# Altium schematic sheet Magnetometer.SchDoc
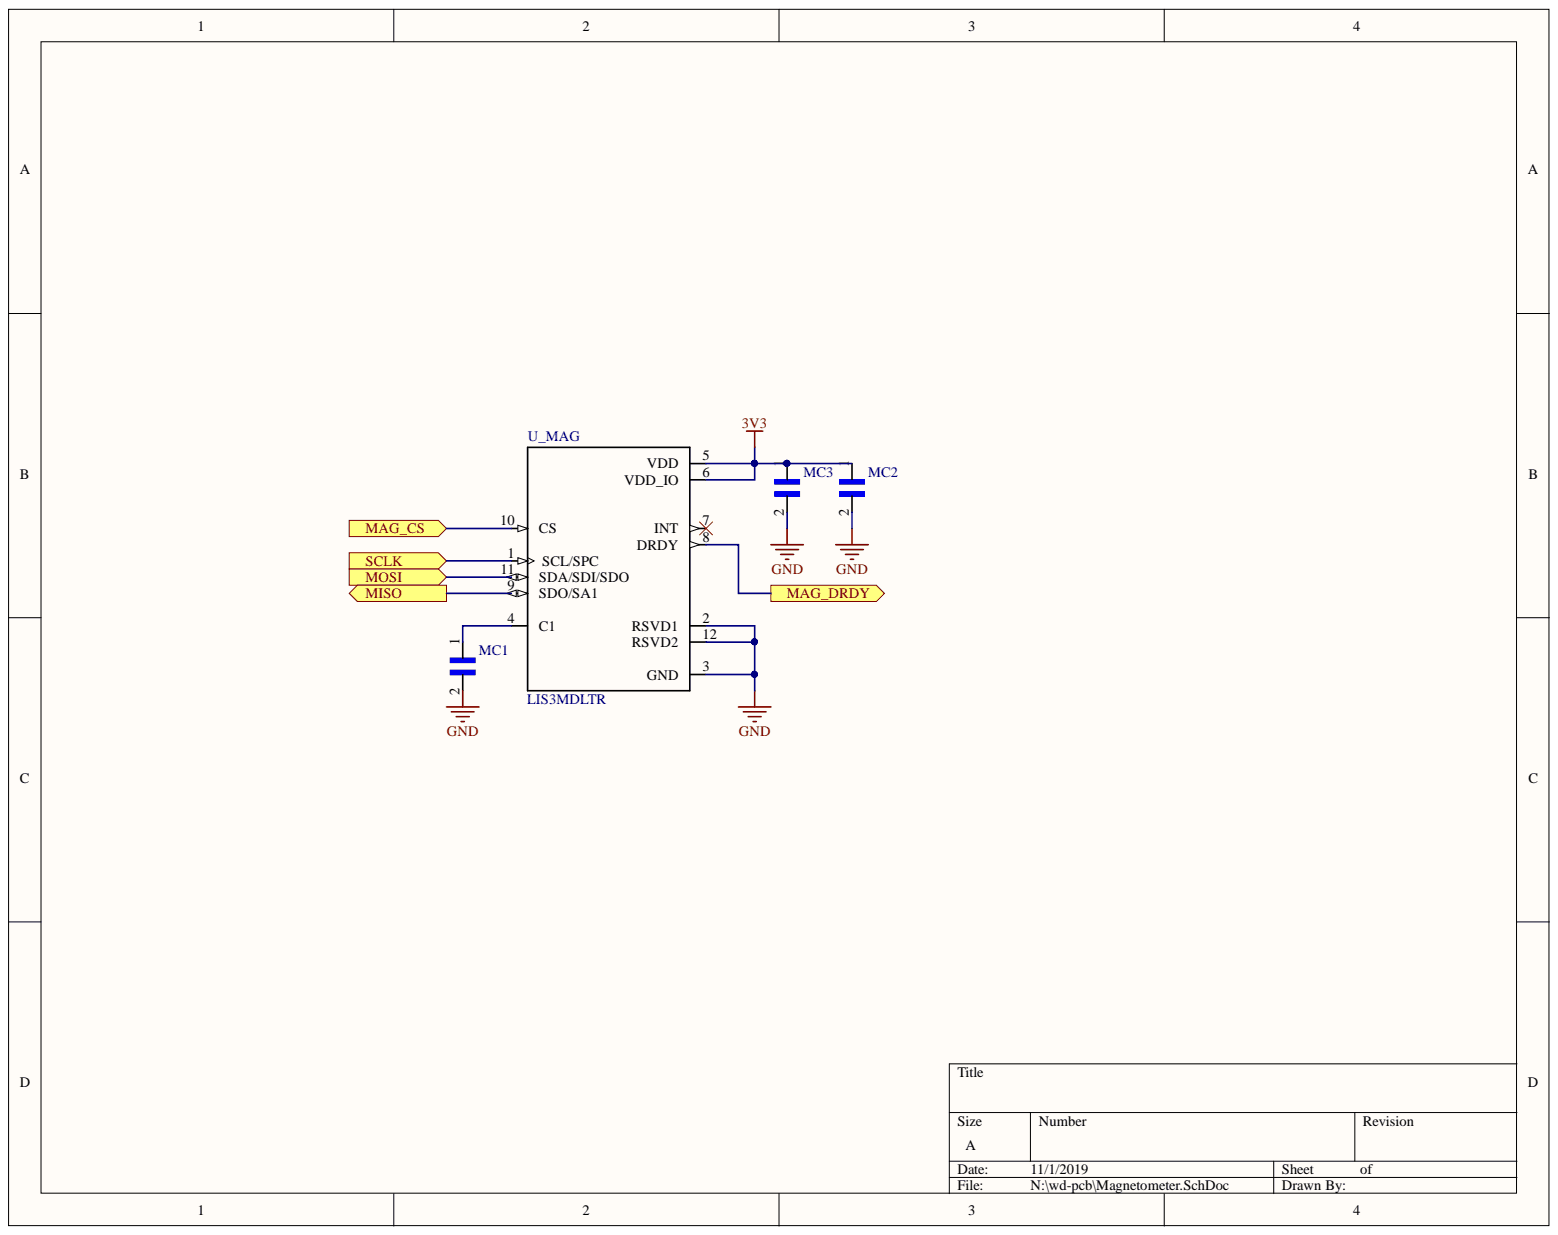
[diagram p0: Magnetometer.SchDoc]

## Parts
MC1  GMK107BJ104KAHT  [*CAPC1608X90N]  (value not drawn)
MC2  TACL105K016XTA  [*CAPC1608X90]  (value not drawn)
MC3  GMK107BJ104KAHT  [*CAPC1608X90N]  (value not drawn)
U_MAG  LIS3MDLTR  [*XDCR_LIS3MDLTR]

## Ports
MAG_CS, MAG_DRDY, MISO, MOSI, SCLK

## Nets
3V3: MC2.1 MC3.1 U_MAG.5(VDD) U_MAG.6(VDD_IO)
GND: MC1.2 MC2.2 MC3.2 U_MAG.2(RSVD1) U_MAG.3(GND) U_MAG.12(RSVD2)
MAG_CS: U_MAG.10(CS) ; port MAG_CS
MAG_DRDY: U_MAG.8(DRDY) ; port MAG_DRDY
MISO: U_MAG.9(SDO/SA1) ; port MISO
MOSI: U_MAG.11(SDA/SDI/SDO) ; port MOSI
SCLK: U_MAG.1(SCL/SPC) ; port SCLK
NetMC1_1: MC1.1 U_MAG.4(C1)

Not connected: U_MAG 7(INT)

## Library data of the parts
MC1 MC3 = GMK107BJ104KAHT: CAP , MLCC , 0603, 35V , X5R, 0.1uF10% , T&R; MF: Taiyo Yuden; Component Kind: Standard; Digi-Key_Part_Number: 587-3357-1-ND; Digi-Key_Purchase_URL: https://www.digikey.com/product-detail/en/taiyo-yuden/GMK107BJ104KAHT/587-3357-1-ND/4157244?utm_source=snapeda&utm_medium=aggregator&utm_campaign=symbol; Library Name: GMK107BJ104KAHT.IntLib; MP: GMK107BJ104KAHT; Package: 1608 Taiyo Yuden; SnapEDA_Link: https://www.snapeda.com/parts/GMK107BJ104KAHT/Taiyo%20Yuden/view-part/?ref=snap
MC2 = TACL105K016XTA: Cap Tant Solid 1uF 16V L CASE 10% _1.6 X 0.85 X 0.85mm_ SMD 1608-10 7.5 Ohm 125Â°C Plastic T/R; MF: AVX; Component Kind: Standard; Digi-Key_Part_Number: 478-4184-1-ND; Digi-Key_Purchase_URL: https://www.digikey.com/product-detail/en/avx-corporation/TACL105K016XTA/478-4184-1-ND/1212747?utm_source=snapeda&utm_medium=aggregator&utm_campaign=symbol; Library Name: TACL105K016XTA.IntLib; MP: TACL105K016XTA; Package: 0603 AVX; SnapEDA_Link: https://www.snapeda.com/parts/TACL105K016XTA/AVX%20/%20Kyocera/view-part/?ref=snap
U_MAG = LIS3MDLTR: LIS3MDL Series 3 Axis Â±2/Â±8/Â±12/Â±16 g 3.6V High Performance Magnetometer LGA-12; MF: STMicroelectronics; Component Kind: Standard; Digi-Key_Part_Number: 497-13892-1-ND; Digi-Key_Purchase_URL: https://www.digikey.com/product-detail/en/stmicroelectronics/LIS3MDLTR/497-13892-1-ND/4309737?utm_source=snapeda&utm_medium=aggregator&utm_campaign=symbol; Library Name: LIS3MDLTR.IntLib; MP: LIS3MDLTR; Package: VFLGA-12 STMicroelectronics; SnapEDA_Link: https://www.snapeda.com/parts/LIS3MDLTR/STMicroelectronics/view-part/?ref=snap
